# Altium schematic sheet RS485.SchDoc(RS485)
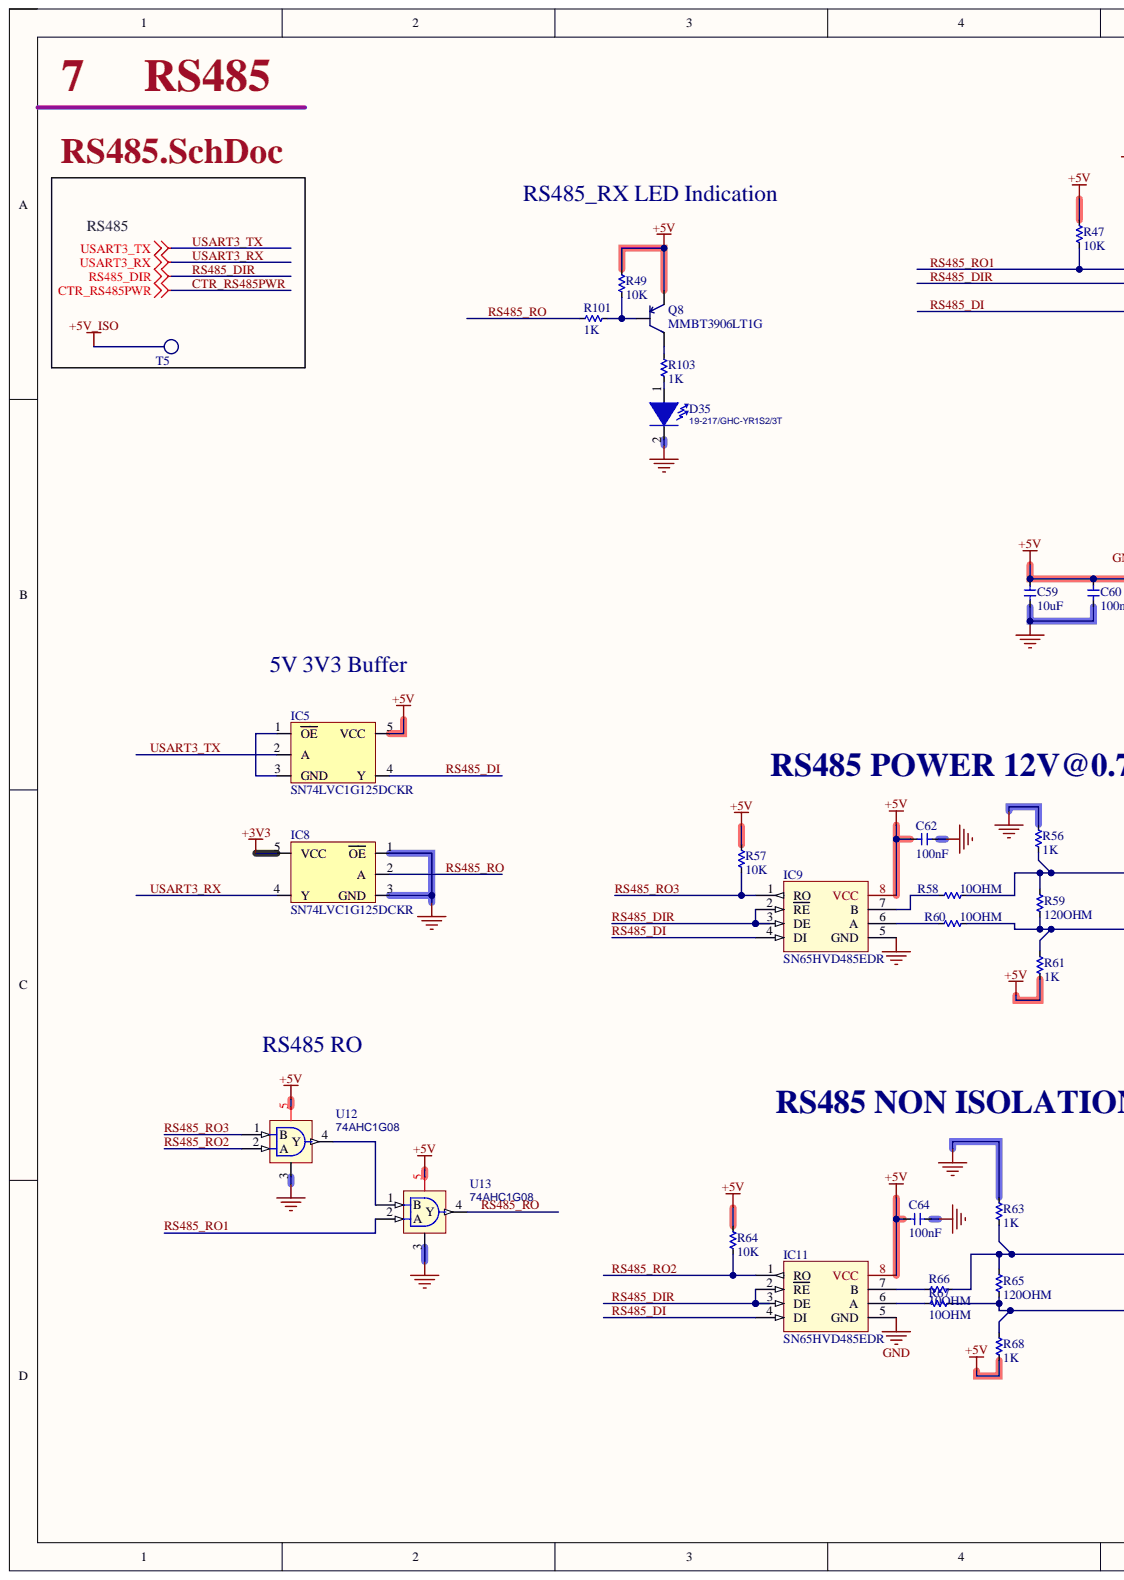
[diagram p0: RS485.SchDoc, left]
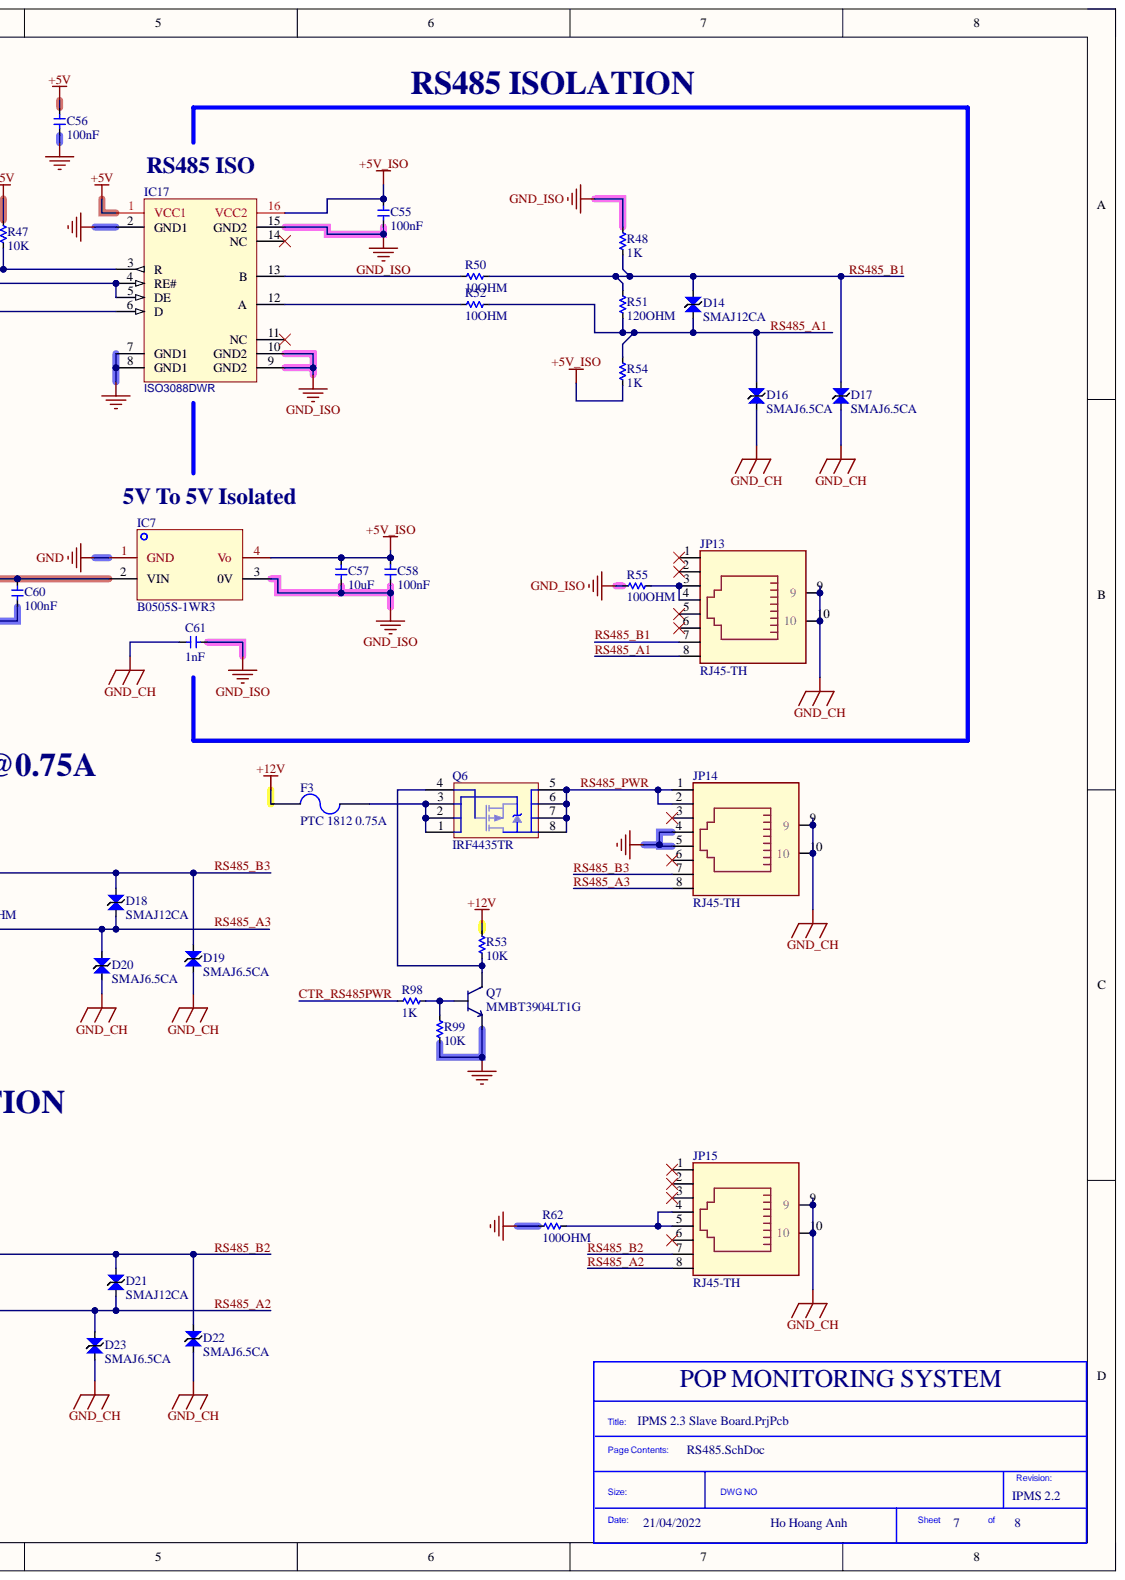
[diagram p1: RS485.SchDoc, right]

## Parts
C55  100nF  [C_0603]
C56  100nF  [C_0603]
C57  10uF  [C_0805]
C58  100nF  [C_0603]
C59  10uF  [C_0805]
C60  100nF  [C_0603]
C61  1nF  [C_1206]
C62  100nF  [C_0603]
C64  100nF  [C_0603]
D14  SMAJ12CA  [SMA_L4.4-W2.6-LS5.0-BI]
D16  SMAJ6.5CA  [SMA_L4.4-W2.6-LS5.0-BI]
D17  SMAJ6.5CA  [SMA_L4.4-W2.6-LS5.0-BI]
D18  SMAJ12CA  [SMA_L4.4-W2.6-LS5.0-BI]
D19  SMAJ6.5CA  [SMA_L4.4-W2.6-LS5.0-BI]
D20  SMAJ6.5CA  [SMA_L4.4-W2.6-LS5.0-BI]
D21  SMAJ12CA  [SMA_L4.4-W2.6-LS5.0-BI]
D22  SMAJ6.5CA  [SMA_L4.4-W2.6-LS5.0-BI]
D23  SMAJ6.5CA  [SMA_L4.4-W2.6-LS5.0-BI]
D35  19-217/GHC-YR1S2/3T  [LED_0603]
F3  PTC 1812 0.75A  Package: 1812  [F_1812]
IC5  SN74LVC1G125DCKR  [SOT-353_L2.2-W1.4-P0.65-LS2.4-BR]
IC7  B0505S-1WR3  [PWRM-TH_BXXXXS-1WR3]
IC8  SN74LVC1G125DCKR  [SOT-353_L2.2-W1.4-P0.65-LS2.4-BR]
IC9  SN65HVD485EDR  [SOIC-8_3.9x4.9x1.27P]
IC11  SN65HVD485EDR  [SOIC-8_3.9x4.9x1.27P]
IC17  ISO3088DWR  [SOIC-16_L10.3-W7.5-P1.27-LS10.3-BL]
JP13  RJ45-TH  [RJ45-TH_R-RJ45R10P-B000]
JP14  RJ45-TH  [RJ45-TH_R-RJ45R10P-B000]
JP15  RJ45-TH  [RJ45-TH_R-RJ45R10P-B000]
Q6  IRF4435TR  [SO-8_L4.9-W3.9-P1.27-LS5.9-BL]
Q7  MMBT3904LT1G  [SOT-23-3]
Q8  MMBT3906LT1G  [SOT-23-3]
R47  10K  [R_0603]
R48  1K  [R_0603]
R49  10K  [R_0603]
R50  10OHM  [R_0805]
R51  120OHM  [R_0805]
R52  10OHM  [R_0805]
R53  10K  [R_0805]
R54  1K  [R_0603]
R55  100OHM  [R_0805]
R56  1K  [R_0603]
R57  10K  [R_0603]
R58  10OHM  [R_0805]
R59  120OHM  [R_0805]
R60  10OHM  [R_0805]
R61  1K  [R_0603]
R62  100OHM  [R_0805]
R63  1K  [R_0603]
R64  10K  [R_0603]
R65  120OHM  [R_0805]
R66  10OHM  [R_0805]
R67  10OHM  [R_0805]
R68  1K  [R_0603]
R98  1K  [R_0603]
R99  10K  [R_0603]
R101  1K  [R_0603]
R103  1K  [R_0603]
T5  TEST-POINT'4545'  [TP-D45MIL]  (value not drawn)  (no BOM)
U12  74AHC1G08  [SOT-353_L2.2-W1.4-P0.65-LS2.4-BR]
U13  74AHC1G08  [SOT-353_L2.2-W1.4-P0.65-LS2.4-BR]

## Nets
+3V3: IC8.5(VCC)
+5V: C56.2 C59.2 C60.2 C62.2 C64.2 IC5.5(VCC) IC7.2(VIN) IC9.8(VCC) IC11.8(VCC) IC17.1(VCC1) Q8.2 R47.1 R49.1 R57.1 R61.2 R64.1 R68.2 U12.5 U13.5
+5V_ISO: C55.2 C57.2 C58.2 IC7.4(Vo) IC17.16(VCC2) R54.2 T5.T
+12V: F3.1 R53.1
GND: C56.1 C59.1 C60.1 C62.1 C64.1 D35.2 IC7.1(GND) IC8.1(OE) IC8.3(GND) IC9.5(GND) IC11.5(GND) IC17.2(GND1) IC17.7(GND1) IC17.8(GND1) JP14.4 JP14.5 Q7.2 R56.1 R62.1 R63.1 R99.2 U12.3 U13.3
GND_CH: C61.1 D16.1 D17.1 D19.1 D20.1 D22.1 D23.1 JP13.9 JP13.10 JP14.9 JP14.10 JP15.9 JP15.10
GND_ISO: C55.1 C57.1 C58.1 C61.2 IC7.3(0V) IC17.9(GND2) IC17.10(GND2) IC17.15(GND2) R48.1 R55.2
CTR_RS485PWR: R98.2
RS485_A1: D14.1 D16.2 JP13.8 R51.2 R52.1 R54.1
RS485_A2: D21.1 D23.2 JP15.8 R65.2 R67.1 R68.1
RS485_A3: D18.1 D20.2 JP14.8 R59.2 R60.1 R61.1
RS485_B1: D14.2 D17.2 JP13.7 R48.2 R50.1 R51.1
RS485_B2: D21.2 D22.2 JP15.7 R63.2 R65.1 R66.1
RS485_B3: D18.2 D19.2 JP14.7 R56.2 R58.1 R59.1
RS485_DI: IC5.4(Y) IC9.4(DI) IC11.4(DI) IC17.6(D)
RS485_DIR: IC9.2(RE) IC9.3(DE) IC11.2(RE) IC11.3(DE) IC17.4(RE#) IC17.5(DE)
RS485_PWR: JP14.1 JP14.2 Q6.5 Q6.6 Q6.7 Q6.8
RS485_RO: IC8.2(A) R101.2 U13.4(Y)
RS485_RO1: IC17.3(R) R47.2 U13.2(A)
RS485_RO2: IC11.1(RO) R64.2 U12.2(A)
RS485_RO3: IC9.1(RO) R57.2 U12.1(B)
USART3_RX: IC8.4(Y)
USART3_TX: IC5.2(A)
NetD35_1: D35.1 R103.2
NetF3_2: F3.2 Q6.1 Q6.2 Q6.3
NetIC5_1: IC5.1(OE) IC5.3(GND)
NetIC9_6: IC9.6(A) R60.2
NetIC9_7: IC9.7(B) R58.2
NetIC11_6: IC11.6(A) R67.2
NetIC11_7: IC11.7(B) R66.2
NetIC17_12: IC17.12(A) R52.2
NetIC17_13: IC17.13(B) R50.2
NetJP13_3: JP13.3 JP13.4 R55.1
NetJP15_4: JP15.4 JP15.5 R62.2
NetQ6_4: Q6.4 Q7.3 R53.2
NetQ7_1: Q7.1 R98.1 R99.1
NetQ8_1: Q8.1 R49.2 R101.1
NetQ8_3: Q8.3 R103.1
NetU12_4: U12.4(Y) U13.1(B)

Not connected: IC17 11(NC) 14(NC); JP13 1 2 5 6; JP14 3 6; JP15 1 2 3 6

## Library data of the parts
C55 C56 C58 C60 C62 C64 = CC0603KRX7R9BB104: 100nF 50V X7R ±10% 0603 Multilayer Ceramic Capacitors MLCC - SMD/SMT ROHS; Manufacturer: YAGEO; Assembly Type: SMT Assembly; Component Kind: Standard; LCSC Part Number: C14663; Manufacturer Part Number: CC0603KRX7R9BB104; Package: 0603; Library Name: IPMS 2.3 Slave Board.SCHLIB
C57 C59 = CL21A106KAYNNNE: 10UF 25V 0805 ROHS; Manufacturer: Samsung Electro-Mechanics; Assembly Type: SMT Assembly; Component Kind: Standard; LCSC Part Number: C15850; Manufacturer Part Number: CL21A106KAYNNNE; Package: 0805; Library Name: IPMS 2.3 Slave Board.SCHLIB
C61 = CC1206JKNPOCBN102: 1nF ±5% 1kV NP0 1206 Multilayer Ceramic Capacitors MLCC - SMD/SMT RoHS; Manufacturer: YAGEO; Assembly Type: SMT Assembly; Component Kind: Standard; LCSC Part Number: C113872; Manufacturer Part Number: CC1206JKNPOCBN102; Package: 1206; Library Name: IPMS 2.3 Slave Board.SCHLIB
D14 D18 D21 = SMAJ12CA: TVS DIODE 12V 19.9V SMA; Manufacturer: MDD（Microdiode Electronics）; Assembly Type: SMT Assembly; Component Kind: Standard; LCSC Part Number: C110042; Manufacturer Part Number: SMAJ12CA; Package: SMA,DO-214AC; Library Name: IPMS 2.3 Slave Board.SCHLIB
D16 D17 D19 D20 D22 D23 = SMAJ6.5CA: SMAJ6.5CA 400W 35.7A 500μA 11.2V 7.22V 6.5V 7.98V Bi-Directional SMA(DO-214AC) TVS ROHS; Manufacturer: MDD（Microdiode Electronics）; Assembly Type: SMT Assembly; Component Kind: Standard; LCSC Part Number: C110041; Manufacturer Part Number: SMAJ6.5CA; Package: SMA,DO-214AC; Library Name: IPMS 2.3 Slave Board.SchLib
D35 = LED_GREEN_0603: LIGHT EMITTING DIODES (LED) GREEN 520~535NM 112~258MCD@20MA TOP VIEW 0603 ROHS; Manufacturer: Everlight Elec; Assembly Type: SMT Assembly; Component Kind: Standard; Digikey Part Number: 19-217/GHC-YR1S2/3T-ND; LCSC Part Number: C72043; Manufacturer Part Number: 19-217/GHC-YR1S2/3T; Package: LED_0603; Library Name: IPMS 2.3 Slave Board.SCHLIB
F3 = PTC_mSMD075-33V: 1812 PTC RESETTABLE FUSES ROHS 24V; Manufacturer: TECHFUSE; Assembly Type: SMT Assembly; Component Kind: Standard; LCSC Part Number: C70117; Manufacturer Part Number: mSMD075-33V; Library Name: IPMS 2.3 Slave Board.SCHLIB
IC5 IC8 = SN74LVC1G125DCKR: 74LVC 1 Buffers，Non-OppositeSide 1 SOT-23-5 Buffers / Drivers ROHS; Manufacturer: Texas Instruments; Assembly Type: SMT Assembly; Component Kind: Standard; LCSC Part Number: C7833; Manufacturer Part Number: SN74LVC1G125DCKR; Package: SOT-353; Library Name: IPMS 2.3 Slave Board.SCHLIB
IC7 = B0505S-1WR3: B0505S-1WR3 5V 200mA 5.5V 1 Plugin Power Modules ROHS; Manufacturer: MORNSUN Guangzhou S& T; Manual Assembly: Yes; Assembly Type: Hand Soldering; Component Kind: Standard; Digikey Part Number: 2725-B0505S-1WR3-ND; LCSC Part Number: C131038; Manufacturer 2: Mornsun America, LLC; Manufacturer Part Number: B0505S-1WR3; Manufacturer Part Number 2: B0505S-1WR3; Package: SIP-4; Library Name: IPMS 2.3 Slave Board.SCHLIB
IC9 IC11 = SN65HVD485EDR: RS-485 & RS-422 1/1 1MBPS 3.15V ~ 5.5V SOIC-8_150MIL ROHS; Manufacturer: Texas Instruments; Assembly Type: SMT Assembly; Component Kind: Standard; LCSC Part Number: C27899; Manufacturer Part Number: SN65HVD485EDR; Package: SOIC-8_3.9x4.9x1.27P; Library Name: IPMS 2.3 Slave Board.SCHLIB
IC17 = ISO3088DWR: 20Mbps RS422, RS485 Transceiver SOIC-16_300mil RS-485/RS-422 ICs ROHS; Manufacturer: Texas Instruments; Assembly Type: SMT Assembly; Component Kind: Standard; LCSC Part Number: C39386; Manufacturer Part Number: ISO3088DWR; Package: SOIC-16_7.5x10.3x1.27P; Library Name: IPMS 2.3 Slave Board.SCHLIB
JP13-JP15 = RJ45R10P-B000: RJ45 18MM, No Transformer; Manufacturer: Ckmtw(Shenzhen Cankemeng); Manufacturer Part Number: R-RJ45R10P-B000; Assembly Type: Hand Soldering; Component Kind: Standard; Digikey Part Number: A31458-ND; LCSC Part Number: C386758; Manufacturer 2: TE Connectivity AMP Connectors; Manufacturer Part Number 2: 5557789-1; Package: Through hole; Library Name: IPMS 2.3 Slave Board.SCHLIB
Q6 = IRF4435TR: P-channel HEXFET; Manufacturer: VBsemi Elec; Assembly Type: SMT Assembly; Component Kind: Standard; LCSC Part Number: C693158; Manufacturer 2: Alpha & Omega Semicon; Manufacturer Part Number: IRF4435TR; Manufacturer Part Number 2: AO4407A; Package: SO-8; Library Name: IPMS 2.3 Slave Board.SCHLIB
Q7 = MMBT3904LT1G: MMBT3904 TRANSISTORS (NPN/PNP) NPN 200MA 40V SOT-23(SOT-23-3) ROHS; Manufacturer: Onsemi; Assembly Type: SMT Assembly; Component Kind: Standard; LCSC Part Number: C81464; Manufacturer Part Number: MMBT3904LT1G; Package: SOT-23-3; Library Name: IPMS 2.3 Slave Board.SCHLIB
Q8 = MMBT3906LT1G: MMBT3906 TRANSISTORS (NPN/PNP) PNP 200MA 40V SOT-23(SOT-23-3) ROHS; Manufacturer: ON Semicon; Assembly Type: SMT Assembly; Component Kind: Standard; LCSC Part Number: C53444; Manufacturer Part Number: MMBT3906LT1G; Package: SOT-23-3; Library Name: IPMS 2.3 Slave Board.SCHLIB
R47 R49 R57 R64 R99 = 0603WAF1002T5E: 10KOHMS ±1% 1/10W 0603 ROHS; Manufacturer: Uniroyal Elec; Assembly Type: SMT Assembly; Component Kind: Standard; LCSC Part Number: C25804; Manufacturer Part Number: 0603WAF1002T5E; Package: 0603; Library Name: IPMS 2.3 Slave Board.SCHLIB
R48 R54 R56 R61 R63 R68 R98 R101 R103 = 0603WAF1001T5E: 1KOHMS ±1% 1/10W 0603 ROHS; Manufacturer: Uniroyal Elec; Assembly Type: SMT Assembly; Component Kind: Standard; LCSC Part Number: C21190; Manufacturer Part Number: 0603WAF1001T5E; Package: 0603; Library Name: IPMS 2.3 Slave Board.SCHLIB
R50 R52 R58 R60 R66 R67 = 0805W8F100JT5E: 10OHMS ±1% 1/8W 0805 ROHS; Manufacturer: Uniroyal Elec; Assembly Type: SMT Assembly; Component Kind: Standard; LCSC Part Number: C17415; Manufacturer Part Number: 0805W8F100JT5E; Package: 0805; Library Name: IPMS 2.3 Slave Board.SCHLIB
R51 R59 R65 = 0805W8F1200T5E: 120OHMS ±1% 1/8W 0805 ROHS; Manufacturer: Uniroyal Elec; Assembly Type: SMT Assembly; Component Kind: Standard; LCSC Part Number: C17437; Manufacturer Part Number: 0805W8F1200T5E; Package: 0805; Library Name: IPMS 2.3 Slave Board.SCHLIB
R53 = 0805W8F1002T5E: 10KOHMS ±1% 1/8W 0805 ROHS; Manufacturer: Uniroyal Elec; Assembly Type: SMT Assembly; Component Kind: Standard; LCSC Part Number: C17414; Manufacturer Part Number: 0805W8F1002T5E; Package: 0805; Library Name: IPMS 2.3 Slave Board.SCHLIB
R55 R62 = 0805W8F1000T5E: 100OHMS ±1% 1/8W 0805 ROHS; Manufacturer: Uniroyal Elec; Assembly Type: SMT Assembly; Component Kind: Standard; LCSC Part Number: C17408; Manufacturer Part Number: 0805W8F1000T5E; Package: 0805; Library Name: IPMS 2.3 Slave Board.SCHLIB
T5 = TEST-POINT'4545': Manufacturer: No; Description: TEST-POINT'4545'; LCSC Part Number: No; Manufacturer Part Number: No; Package: No; Library Name: IPMS 2.3 Slave Board.SchLib
U12 U13 = SN74AHC1G08DCKR: 74 SERIES AND GATE 1 2V ~ 5.5V SOT-353 ROHS; Manufacturer: Texas Instruments; Assembly Type: SMT Assembly; Component Kind: Standard; LCSC Part Number: C122838; Manufacturer Part Number: SN74AHC1G08DCKR; Package: SOT-353; Library Name: IPMS 2.3 Slave Board.SCHLIB
